# Altium schematic sheet B1_MiniPCIE_Wifi.SchDoc(B1_MiniPCIE_Wifi)
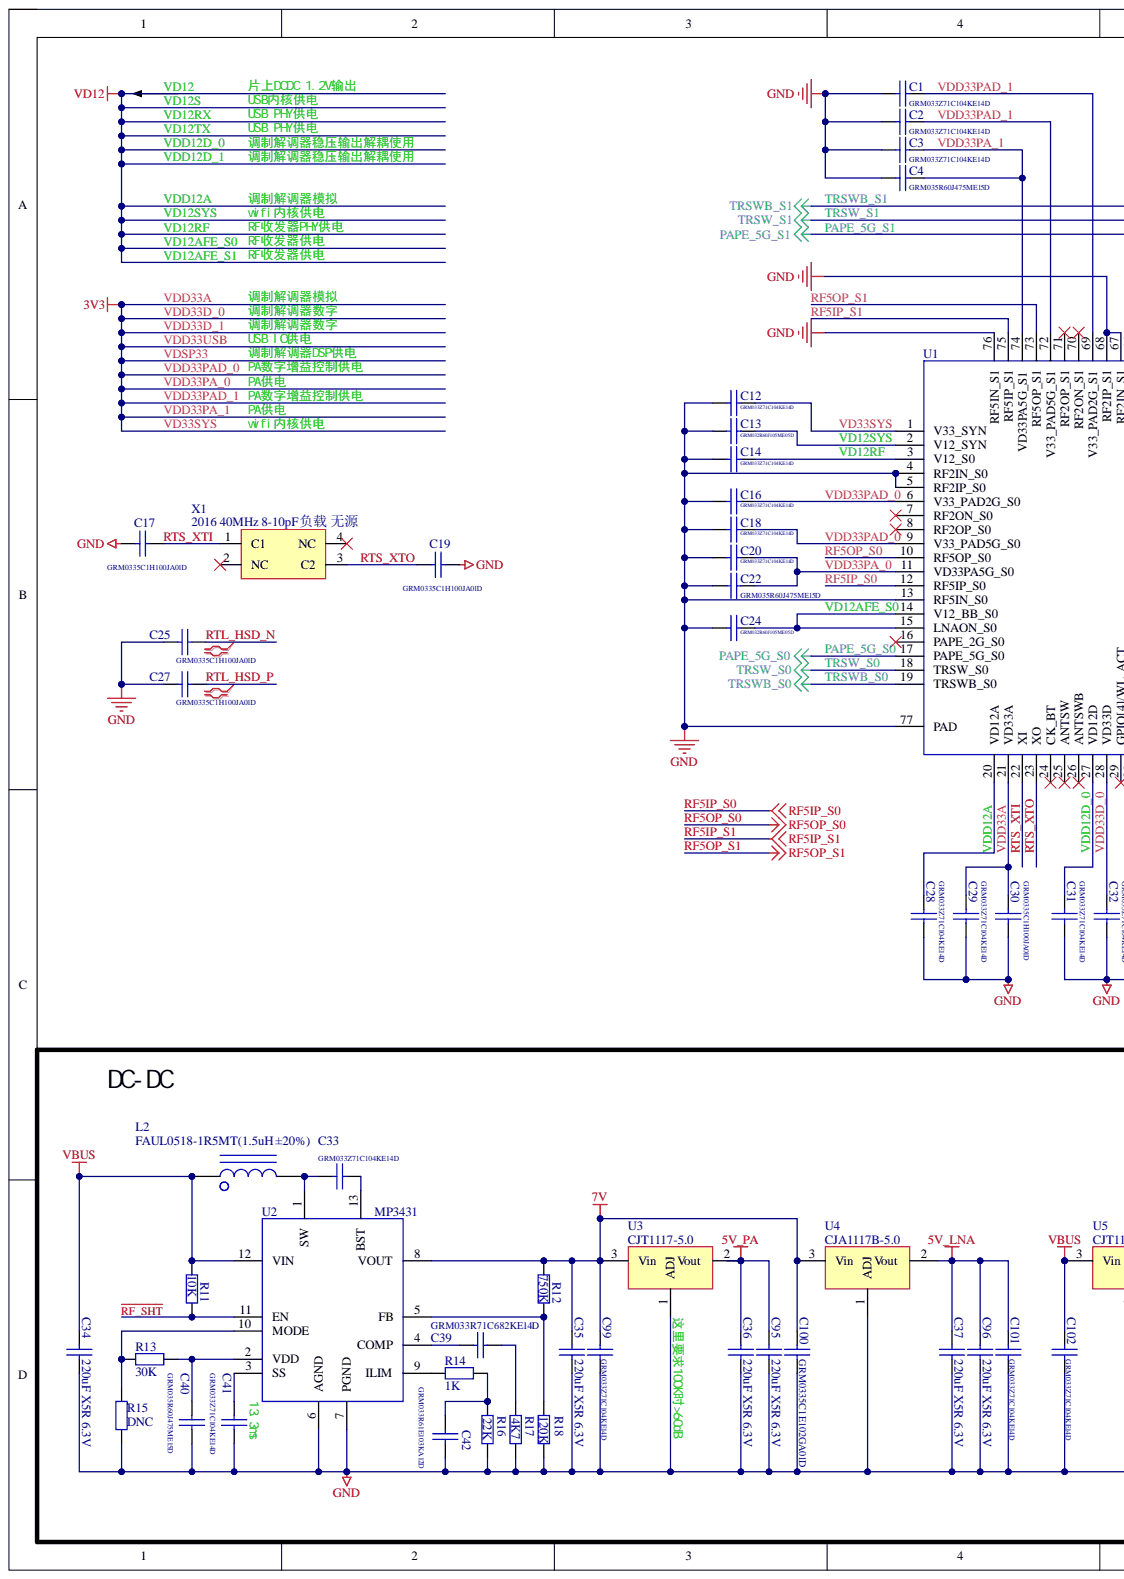
[diagram p0: B1_MiniPCIE_Wifi.SchDoc, left]
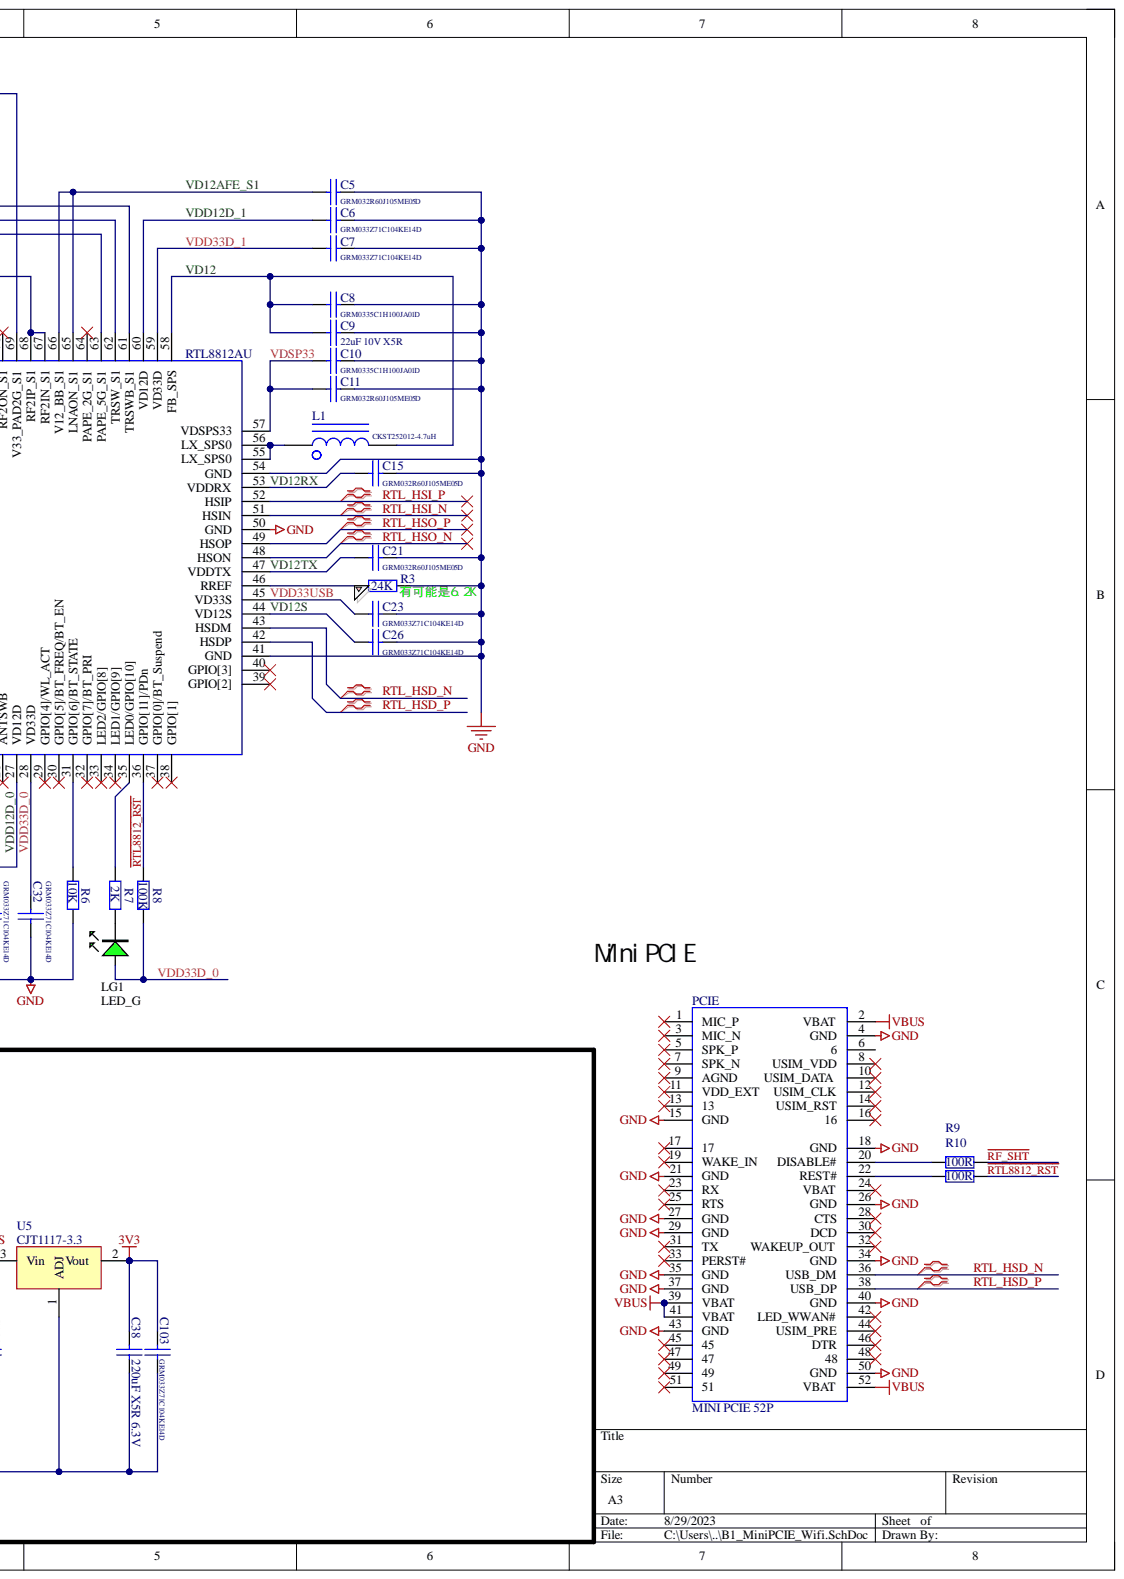
[diagram p1: B1_MiniPCIE_Wifi.SchDoc, right]

## Parts
C1  GRM033Z71C104KE14D  [0201_C]
C2  GRM033Z71C104KE14D  [0201_C]
C3  GRM033Z71C104KE14D  [0201_C]
C4  GRM035R60J475ME15D  [0201_C]
C5  GRM032R60J105ME05D  [0201_C]
C6  GRM033Z71C104KE14D  [0201_C]
C7  GRM033Z71C104KE14D  [0201_C]
C8  GRM0335C1H100JA01D  [0201_c]
C9  22uF 10V X5R  [0603_C]
C10  GRM0335C1H100JA01D  [0201_c]
C11  GRM032R60J105ME05D  [0201_C]
C12  GRM033Z71C104KE14D  [0201_C]
C13  GRM032R60J105ME05D  [0201_C]
C14  GRM033Z71C104KE14D  [0201_C]
C15  GRM032R60J105ME05D  [0201_C]
C16  GRM033Z71C104KE14D  [0201_C]
C17  GRM0335C1H100JA01D  [0201_c]
C18  GRM033Z71C104KE14D  [0201_C]
C19  GRM0335C1H100JA01D  [0201_c]
C20  GRM033Z71C104KE14D  [0201_C]
C21  GRM032R60J105ME05D  [0201_C]
C22  GRM035R60J475ME15D  [0201_C]
C23  GRM033Z71C104KE14D  [0201_C]
C24  GRM032R60J105ME05D  [0201_C]
C25  GRM0335C1H100JA01D  [0201_c]
C26  GRM033Z71C104KE14D  [0201_C]
C27  GRM0335C1H100JA01D  [0201_c]
C28  GRM033Z71C104KE14D  [0201_C]
C29  GRM033Z71C104KE14D  [0201_C]
C30  GRM0335C1H100JA01D  [0201_c]
C31  GRM033Z71C104KE14D  [0201_C]
C32  GRM033Z71C104KE14D  [0201_C]
C33  GRM033Z71C104KE14D  [0201_C]
C34  220uF X5R 6.3V  [1206_C]
C35  220uF X5R 6.3V  [1206_C]
C36  220uF X5R 6.3V  [1206_C]
C37  220uF X5R 6.3V  [1206_C]
C38  220uF X5R 6.3V  [1206_C]
C39  GRM033R71C682KE14D  [0201_C]
C40  GRM035R60J475ME15D  [0201_C]
C41  GRM033Z71C104KE14D  [0201_C]
C42  GRM033R61E103KA12D  [0201_C]
C95  220uF X5R 6.3V  [1206_C]
C96  220uF X5R 6.3V  [1206_C]
C99  GRM033Z71C104KE14D  [0201_C]
C100  GRM0335C1E102GA01D  [0201_C]
C101  GRM033Z71C104KE14D  [0201_C]
C102  GRM033Z71C104KE14D  [0201_C]
C103  GRM033Z71C104KE14D  [0201_C]
L1  CKST252012-4.7uH  [L1008_YT]
L2  FAUL0518-1R5MT(1.5uH ±20%)  [L0518]
LG1  LED_G  [0603_LED_S1]
PCIE  MINI PCIE 52P  [RG25 MINIPCIE K]  (no BOM)
R3  24K  [0402_R]
R6  10K  [0201_R]
R7  2K  [0201_R]
R8  100K  [0201_R]
R9  100R  [0201_R]
R10  100R  [0201_R]
R11  10K  [0201_R]
R12  750K  [0201_R]
R13  30K  [0201_R]
R14  1K  [0201_R]
R15  DNC  [0201_R]
R16  22K  [0201_R]
R17  4K7  [0201_R]
R18  120K  [0201_R]
U1  RTL8812AU  [QFN76]
U2  MP3431  [VFQFN-13]
U3  CJT1117-5.0  [SOT-223]
U4  CJA1117B-5.0  [SOT-89(SOT-89-3)]
U5  CJT1117-3.3  [SOT-223]
X1  2016 40MHz 8-10pF负载 无源  封装: 2016  [XTAL_2016]

## Nets
5V_LNA: C37.2 C96.2 C101.2 U4.2
5V_PA: C36.2 C95.2 U3.2
7V: C35.2 C99.1 C100.2 R12.2 U2.8(VOUT) U3.3 U4.3
GND: C1.2 C2.2 C3.2 C4.1 C5.2 C6.1 C7.1 C8.2 C9.1 C10.2 C11.2 C12.2 C13.1 C14.2 C15.2 C16.2 C17.1 C18.2 C19.2 C20.2 C21.2 C22.1 C23.1 C24.1 C25.1 C26.1 C27.1 C28.1 C29.1 C30.2 C31.1 C32.1 C34.1 C35.1 C36.1 C37.1 C38.1 C40.2 C41.2 C42.2 C95.1 C96.1 C99.2 C100.1 C101.1 C102.1 C103.1 PCIE.4(GND) PCIE.15(GND) PCIE.18(GND) PCIE.21(GND) PCIE.26(GND) PCIE.27(GND) PCIE.29(GND) PCIE.34(GND) PCIE.35(GND) PCIE.37(GND) PCIE.40(GND) PCIE.43(GND) PCIE.50(GND) R3.1 R6.1 R15.2 R16.2 R17.2 R18.1 U1.4(RF2IN_S0) U1.5(RF2IP_S0) U1.13(RF5IN_S0) U1.41(GND) U1.50(GND) U1.54(GND) U1.67(RF2IN_S1) U1.68(RF2IP_S1) U1.76(RF5IN_S1) U1.77(PAD) U2.6(AGND) U2.7(PGND) U3.1 U4.1 U5.1
VBUS: C34.2 C102.2 L2.1 PCIE.2(VBAT) PCIE.39(VBAT) PCIE.41(VBAT) PCIE.52(VBAT) R11.2 U2.12(VIN) U5.3
PAPE_5G_S0: U1.17(PAPE_5G_S0)
PAPE_5G_S1: U1.63(PAPE_5G_S1)
~{RF_SHT}: R9.2 R11.1 U2.11(EN)
~{RTL8812_RST}: R8.2 R10.2 U1.36(GPIO[11]/PDn)
RF5IP_S0: U1.12(RF5IP_S0)
RF5IP_S1: U1.75(RF5IP_S1)
RF5OP_S0: U1.10(RF5OP_S0)
RF5OP_S1: U1.73(RF5OP_S1)
RTL_HSD_N: C25.2 PCIE.36(USB_DM) U1.43(HSDM)
RTL_HSD_P: C27.2 PCIE.38(USB_DP) U1.42(HSDP)
RTL_HSI_N: U1.51(HSIN)
RTL_HSI_P: U1.52(HSIP)
RTL_HSO_N: U1.48(HSON)
RTL_HSO_P: U1.49(HSOP)
RTS_XTI: C17.2 U1.22(XI) X1.1(C1)
RTS_XTO: C19.1 U1.23(XO) X1.3(C2)
TRSW_S0: U1.18(TRSW_S0)
TRSW_S1: U1.62(TRSW_S1)
TRSWB_S0: U1.19(TRSWB_S0)
TRSWB_S1: U1.61(TRSWB_S1)
VD12: C5.1 C6.2 C8.1 C9.2 C13.2 C14.1 C15.1 C21.1 C24.2 C26.2 C28.2 C31.2 L1.2 U1.2(V12_SYN) U1.3(V12_S0) U1.14(V12_BB_S0) U1.15(LNAON_S0) U1.20(VD12A) U1.27(VD12D) U1.44(VD12S) U1.47(VDDTX) U1.53(VDDRX) U1.58(FB_SPS) U1.60(VD12D) U1.65(LNAON_S1) U1.66(V12_BB_S1)
VD33SYS: C1.1 C2.1 C3.1 C4.2 C7.2 C10.1 C11.1 C12.1 C16.1 C18.1 C20.1 C22.2 C23.2 C29.2 C30.1 C32.2 C38.2 C103.2 LG1.A R8.1 U1.1(V33_SYN) U1.6(V33_PAD2G_S0) U1.9(V33_PAD5G_S0) U1.11(VD33PA5G_S0) U1.21(VD33A) U1.28(VD33D) U1.45(VD33S) U1.57(VDSPS33) U1.59(VD33D) U1.69(V33_PAD2G_S1) U1.72(V33_PAD5G_S1) U1.74(VD33PA5G_S1) U5.2
NetC33_1: C33.1 U2.13(BST)
NetC33_2: C33.2 L2.2 U2.1(SW)
NetC39_1: C39.1 U2.4(COMP)
NetC39_2: C39.2 R17.1
NetC40_1: C40.1 R13.1 U2.2(VDD)
NetC41_1: C41.1 U2.3(SS)
NetC42_1: C42.1 R14.1 R16.1
NetL1_1: L1.1 U1.55(LX_SPS0) U1.56(LX_SPS0)
NetLG1_K: LG1.K R7.1
NetPCIE_20: PCIE.20(DISABLE#) R9.1
NetPCIE_22: PCIE.22(REST#) R10.1
NetR3_2: R3.2 U1.46(RREF)
NetR6_2: R6.2 U1.31(GPIO[6]/BT_STATE)
NetR7_2: R7.2 U1.35(LED0/GPIO[10])
NetR12_1: R12.1 R18.2 U2.5(FB)
NetR13_2: R13.2 R15.1 U2.10(MODE)
NetR14_2: R14.2 U2.9(ILIM)

Not connected: PCIE 1(MIC_P) 3(MIC_N) 5(SPK_P) 6 7(SPK_N) 8(USIM_VDD) 9(AGND) 10(USIM_DATA) 11(VDD_EXT) 12(USIM_CLK) 13 14(USIM_RST) 16 17 19(WAKE_IN) 23(RX) 24(VBAT) 25(RTS) 28(CTS) 30(DCD) 31(TX) 32(WAKEUP_OUT) 33(PERST#) 42(LED_WWAN#) 44(USIM_PRE) 45 46(DTR) 47 48 49 51; U1 7(RF2ON_S0) 8(RF2OP_S0) 16(PAPE_2G_S0) 24(CK_BT) 25(ANTSW) 26(ANTSWB) 29(GPIO[4]/WL_ACT) 30(GPIO[5]/BT_FREQ/BT_EN) 32(GPIO[7]/BT_PRI) 33(LED2/GPIO[8]) 34(LED1/GPIO[9]) 37(GPIO[0]/BT_Suspend) 38(GPIO[1]) 39(GPIO[2]) 40(GPIO[3]) 64(PAPE_2G_S1) 70(RF2ON_S1) 71(RF2OP_S1); X1 2(NC) 4(NC)

## Library data of the parts
C1-C8 C10-C33 C39-C42 C99-C103 = CAP: 贴片陶瓷电容; 价格: 0.03; 封装: 0201; Component Kind: Standard; Library Name: 常用元件.SchLib
C9 = CAP: 贴片陶瓷电容; 价格: 0.3; 封装: 0603; Component Kind: Standard; Library Name: 常用元件.SchLib
C34-C38 C95 C96 = CAP: 贴片陶瓷电容; 价格: 0.6; 封装: 1206; Component Kind: Standard; Library Name: 常用元件.SchLib
L1 = SLO0420H: 2.5x2mm一体成型功率电感; 价格: 1; Component Kind: Standard; 封装: 2.5x2mm; Library Name: 切纸机.SchLib
L2 = SLO0420H: 0518一体成型功率电感; 价格: 1; 封装: SMD,5.2x5.4x1.8mm; Component Kind: Standard; Library Name: 切纸机.SchLib
LG1 = LED1_R: 贴片LED 黄绿色; 价格: 0.15; 封装: 0603; Component Kind: Standard; Library Name: 常用元件.LIB
PCIE = MINIPCIE-SMD_MINIPCI-E_SLOT: Library Name: 常用IC.LIB
R3 = Res2: 贴片电阻 精度1%; 价格: 0.01; 封装: 0402; Component Kind: Standard; Library Name: Miscellaneous Devices.IntLib; Signal Integrity: Res; Simulation: RESISTOR
R6-R18 = Res2: 贴片电阻 精度1%; 价格: 0.01; 封装: 0201; Component Kind: Standard; Library Name: Miscellaneous Devices.IntLib; Signal Integrity: Res; Simulation: RESISTOR
U1 = RTL8812AU: SINGLE-CHIP IEEE 802.11ac 2T2R WLAN  CONTROLLER WITH USB 2.0/3.0  INTERFACE; 价格: 25; 封装: QFN-76; Component Kind: Standard; Library Name: 常用IC.LIB
U2 = MP3431: HIGH-EFFICIENCY, SYNC, BOOST CONVERTER W/ PROG CURRENT LIMIT; 价格: 11; Component Kind: Standard; Library Name: 常用IC.LIB; 封装: VFQFN-13
U3 U5 = LM317: 三端稳压器 PSRR 75dB; 三伺服S曲线: 0; 价格: 0.3; Component Kind: Standard; 三伺服切压推独立运行: 0; 三伺服切纸S曲线: 0; 三伺服推纸S曲线: 0; 三伺服斜坡: 0; 双伺服切压推独立运行: 0; 双伺服切纸S曲线+步进斜坡: 0; 双伺服双S曲线+步进S曲线: 0; 双伺服双S曲线+步进斜坡: 0; 双伺服斜坡+步进S曲线: 0; 双伺服斜坡+步进斜坡: 0; 封装: SOT-223; Library Name: 稳压器件.LIB
U4 = LM317: 三端稳压器; 价格: 0.3; 焊接: 是; Component Kind: Standard; 封装: SOT-89(SOT-89-3); Library Name: 稳压器件.LIB
X1 = XTAL_3225: Component Kind: Standard; Description: 2016 40MHz 8-10pF负载 无源; Library Name: SCH_Oscillator.SchLib
